# Altium schematic sheet ResistorDecadeBox.SchDoc
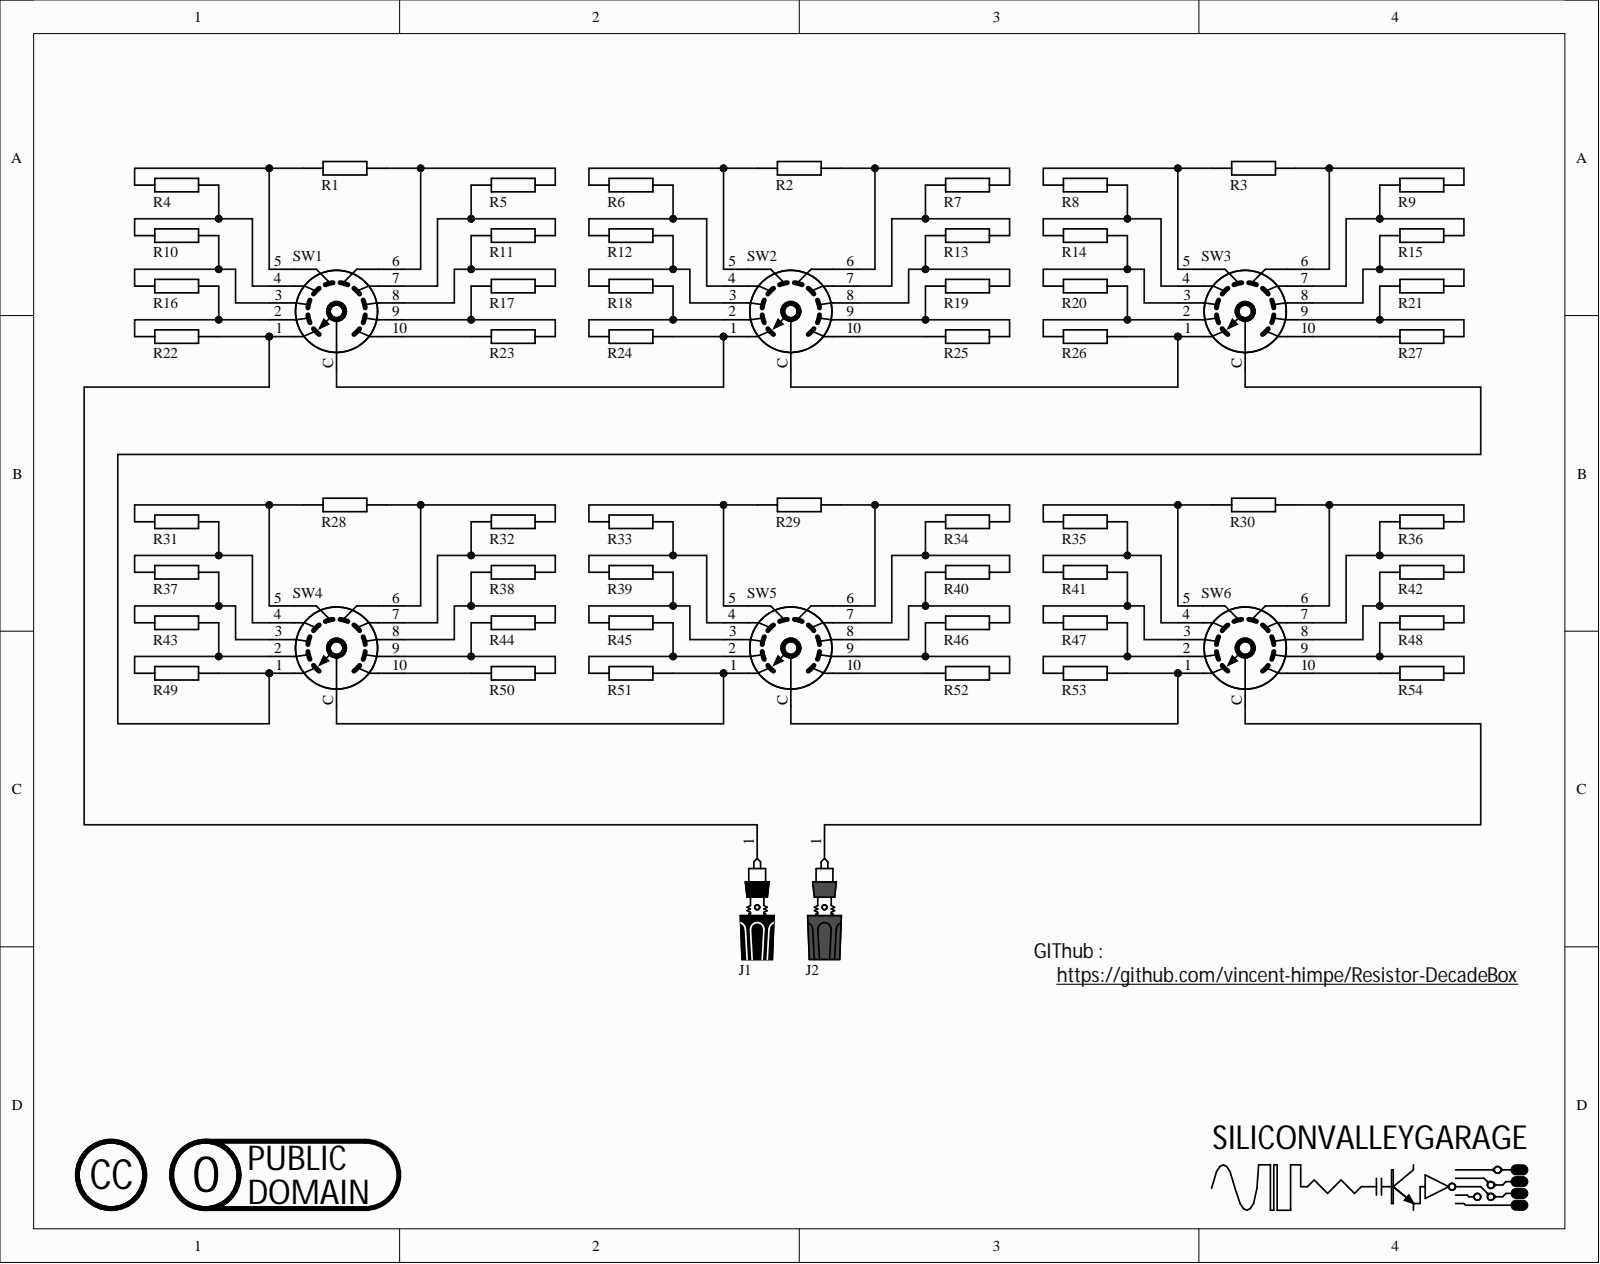
[diagram p0: ResistorDecadeBox.SchDoc]

## Parts
J1  BANANA BLACK, CHASSIS  (value not drawn)
J2  BANANA RED, CHASSIS  (value not drawn)
LOGO1  LOGO-LICENSE-CC0-PUBLIC  [LOGO-LICENSE-CC0-PUBLIC]  (value not drawn)  (no BOM)
LOGO2  LOGO-LICENSE-CC  [LOGO-LICENSE-CC]  (value not drawn)  (no BOM)
LOGO3  SILICONVALLEYGARAGE  [SILICONVALLEYGARAGE]
R1  GENERIC-RES  [RES-YAGEO-FMP300-VERT]  (value not drawn)
R2  GENERIC-RES  [RES-YAGEO-FMP300-VERT]  (value not drawn)
R3  GENERIC-RES  [RES-YAGEO-FMP300-VERT]  (value not drawn)
R4  GENERIC-RES  [RES-YAGEO-FMP300-VERT]  (value not drawn)
R5  GENERIC-RES  [RES-YAGEO-FMP300-VERT]  (value not drawn)
R6  GENERIC-RES  [RES-YAGEO-FMP300-VERT]  (value not drawn)
R7  GENERIC-RES  [RES-YAGEO-FMP300-VERT]  (value not drawn)
R8  GENERIC-RES  [RES-YAGEO-FMP300-VERT]  (value not drawn)
R9  GENERIC-RES  [RES-YAGEO-FMP300-VERT]  (value not drawn)
R10  GENERIC-RES  [RES-YAGEO-FMP300-VERT]  (value not drawn)
R11  GENERIC-RES  [RES-YAGEO-FMP300-VERT]  (value not drawn)
R12  GENERIC-RES  [RES-YAGEO-FMP300-VERT]  (value not drawn)
R13  GENERIC-RES  [RES-YAGEO-FMP300-VERT]  (value not drawn)
R14  GENERIC-RES  [RES-YAGEO-FMP300-VERT]  (value not drawn)
R15  GENERIC-RES  [RES-YAGEO-FMP300-VERT]  (value not drawn)
R16  GENERIC-RES  [RES-YAGEO-FMP300-VERT]  (value not drawn)
R17  GENERIC-RES  [RES-YAGEO-FMP300-VERT]  (value not drawn)
R18  GENERIC-RES  [RES-YAGEO-FMP300-VERT]  (value not drawn)
R19  GENERIC-RES  [RES-YAGEO-FMP300-VERT]  (value not drawn)
R20  GENERIC-RES  [RES-YAGEO-FMP300-VERT]  (value not drawn)
R21  GENERIC-RES  [RES-YAGEO-FMP300-VERT]  (value not drawn)
R22  GENERIC-RES  [RES-YAGEO-FMP300-VERT]  (value not drawn)
R23  GENERIC-RES  [RES-YAGEO-FMP300-VERT]  (value not drawn)
R24  GENERIC-RES  [RES-YAGEO-FMP300-VERT]  (value not drawn)
R25  GENERIC-RES  [RES-YAGEO-FMP300-VERT]  (value not drawn)
R26  GENERIC-RES  [RES-YAGEO-FMP300-VERT]  (value not drawn)
R27  GENERIC-RES  [RES-YAGEO-FMP300-VERT]  (value not drawn)
R28  GENERIC-RES  [RES-YAGEO-FMP300-VERT]  (value not drawn)
R29  GENERIC-RES  [RES-YAGEO-FMP300-VERT]  (value not drawn)
R30  GENERIC-RES  [RES-YAGEO-FMP300-VERT]  (value not drawn)
R31  GENERIC-RES  [RES-YAGEO-FMP300-VERT]  (value not drawn)
R32  GENERIC-RES  [RES-YAGEO-FMP300-VERT]  (value not drawn)
R33  GENERIC-RES  [RES-YAGEO-FMP300-VERT]  (value not drawn)
R34  GENERIC-RES  [RES-YAGEO-FMP300-VERT]  (value not drawn)
R35  GENERIC-RES  [RES-YAGEO-FMP300-VERT]  (value not drawn)
R36  GENERIC-RES  [RES-YAGEO-FMP300-VERT]  (value not drawn)
R37  GENERIC-RES  [RES-YAGEO-FMP300-VERT]  (value not drawn)
R38  GENERIC-RES  [RES-YAGEO-FMP300-VERT]  (value not drawn)
R39  GENERIC-RES  [RES-YAGEO-FMP300-VERT]  (value not drawn)
R40  GENERIC-RES  [RES-YAGEO-FMP300-VERT]  (value not drawn)
R41  GENERIC-RES  [RES-YAGEO-FMP300-VERT]  (value not drawn)
R42  GENERIC-RES  [RES-YAGEO-FMP300-VERT]  (value not drawn)
R43  GENERIC-RES  [RES-YAGEO-FMP300-VERT]  (value not drawn)
R44  GENERIC-RES  [RES-YAGEO-FMP300-VERT]  (value not drawn)
R45  GENERIC-RES  [RES-YAGEO-FMP300-VERT]  (value not drawn)
R46  GENERIC-RES  [RES-YAGEO-FMP300-VERT]  (value not drawn)
R47  GENERIC-RES  [RES-YAGEO-FMP300-VERT]  (value not drawn)
R48  GENERIC-RES  [RES-YAGEO-FMP300-VERT]  (value not drawn)
R49  GENERIC-RES  [RES-YAGEO-FMP300-VERT]  (value not drawn)
R50  GENERIC-RES  [RES-YAGEO-FMP300-VERT]  (value not drawn)
R51  GENERIC-RES  [RES-YAGEO-FMP300-VERT]  (value not drawn)
R52  GENERIC-RES  [RES-YAGEO-FMP300-VERT]  (value not drawn)
R53  GENERIC-RES  [RES-YAGEO-FMP300-VERT]  (value not drawn)
R54  GENERIC-RES  [RES-YAGEO-FMP300-VERT]  (value not drawn)
SW1  SWITCH-ROTARY-1X10  [SWITCH-ALPHA-SR2513F-0110]  (value not drawn)
SW2  SWITCH-ROTARY-1X10  [SWITCH-ALPHA-SR2513F-0110]  (value not drawn)
SW3  SWITCH-ROTARY-1X10  [SWITCH-ALPHA-SR2513F-0110]  (value not drawn)
SW4  SWITCH-ROTARY-1X10  [SWITCH-ALPHA-SR2513F-0110]  (value not drawn)
SW5  SWITCH-ROTARY-1X10  [SWITCH-ALPHA-SR2513F-0110]  (value not drawn)
SW6  SWITCH-ROTARY-1X10  [SWITCH-ALPHA-SR2513F-0110]  (value not drawn)

## Nets
NetJ1_1: J1.1 R22.2 SW1.1
NetJ2_1: J2.1 SW6.C
NetR1_1: R1.1 R5.2 SW1.6
NetR1_2: R1.2 R4.1 SW1.5
NetR2_1: R2.1 R6.1 SW2.5
NetR2_2: R2.2 R7.2 SW2.6
NetR3_1: R3.1 R9.2 SW3.6
NetR3_2: R3.2 R8.1 SW3.5
NetR4_2: R4.2 R10.1 SW1.4
NetR5_1: R5.1 R11.2 SW1.7
NetR6_2: R6.2 R12.1 SW2.4
NetR7_1: R7.1 R13.2 SW2.7
NetR8_2: R8.2 R14.1 SW3.4
NetR9_1: R9.1 R15.2 SW3.7
NetR10_2: R10.2 R16.1 SW1.3
NetR11_1: R11.1 R17.2 SW1.8
NetR12_2: R12.2 R18.1 SW2.3
NetR13_1: R13.1 R19.2 SW2.8
NetR14_2: R14.2 R20.1 SW3.3
NetR15_1: R15.1 R21.2 SW3.8
NetR16_2: R16.2 R22.1 SW1.2
NetR17_1: R17.1 R23.2 SW1.9
NetR18_2: R18.2 R24.1 SW2.2
NetR19_1: R19.1 R25.2 SW2.9
NetR20_2: R20.2 R26.1 SW3.2
NetR21_1: R21.1 R27.2 SW3.9
NetR23_1: R23.1 SW1.10
NetR24_2: R24.2 SW1.C SW2.1
NetR25_1: R25.1 SW2.10
NetR26_2: R26.2 SW2.C SW3.1
NetR27_1: R27.1 SW3.10
NetR28_1: R28.1 R32.2 SW4.6
NetR28_2: R28.2 R31.1 SW4.5
NetR29_1: R29.1 R34.2 SW5.6
NetR29_2: R29.2 R33.1 SW5.5
NetR30_1: R30.1 R36.2 SW6.6
NetR30_2: R30.2 R35.1 SW6.5
NetR31_2: R31.2 R37.1 SW4.4
NetR32_1: R32.1 R38.2 SW4.7
NetR33_2: R33.2 R39.1 SW5.4
NetR34_1: R34.1 R40.2 SW5.7
NetR35_2: R35.2 R41.1 SW6.4
NetR36_1: R36.1 R42.2 SW6.7
NetR37_2: R37.2 R43.1 SW4.3
NetR38_1: R38.1 R44.2 SW4.8
NetR39_2: R39.2 R45.1 SW5.3
NetR40_1: R40.1 R46.2 SW5.8
NetR41_2: R41.2 R47.1 SW6.3
NetR42_1: R42.1 R48.2 SW6.8
NetR43_2: R43.2 R49.1 SW4.2
NetR44_1: R44.1 R50.2 SW4.9
NetR45_2: R45.2 R51.1 SW5.2
NetR46_1: R46.1 R52.2 SW5.9
NetR47_2: R47.2 R53.1 SW6.2
NetR48_1: R48.1 R54.2 SW6.9
NetR49_2: R49.2 SW3.C SW4.1
NetR50_1: R50.1 SW4.10
NetR51_2: R51.2 SW4.C SW5.1
NetR52_1: R52.1 SW5.10
NetR53_2: R53.2 SW5.C SW6.1
NetR54_1: R54.1 SW6.10

## Library data of the parts
J1 = CONN-CLIFF-CL1505: Component Kind: Standard; Library Name: CONNECTORS.SchLib
J2 = CONN-CLIFF-CL1506: Component Kind: Standard; Library Name: CONNECTORS.SchLib
LOGO1 = LOGO-LICENSE-CC0-PUBLIC: Library Name: LOGO.SCHLIB
LOGO2 = LOGO-LICENSE-CC: Library Name: LOGO.SCHLIB
LOGO3 = SILICONVALLEYGARAGE: Component Kind: Standard; Library Name: LOGO.SCHLIB
R1-R54 = GENERIC-RES: Component Kind: Standard; Library Name: PASSIVES.SchLib
SW1-SW6 = SWITCH-ROTARY-1X10: Component Kind: Standard; Library Name: SWITCH.SchLib
